# Altium schematic sheet PowerSupplyHammondMainRev1b.SchDoc(PowerSupplyHammondMainRev1b)
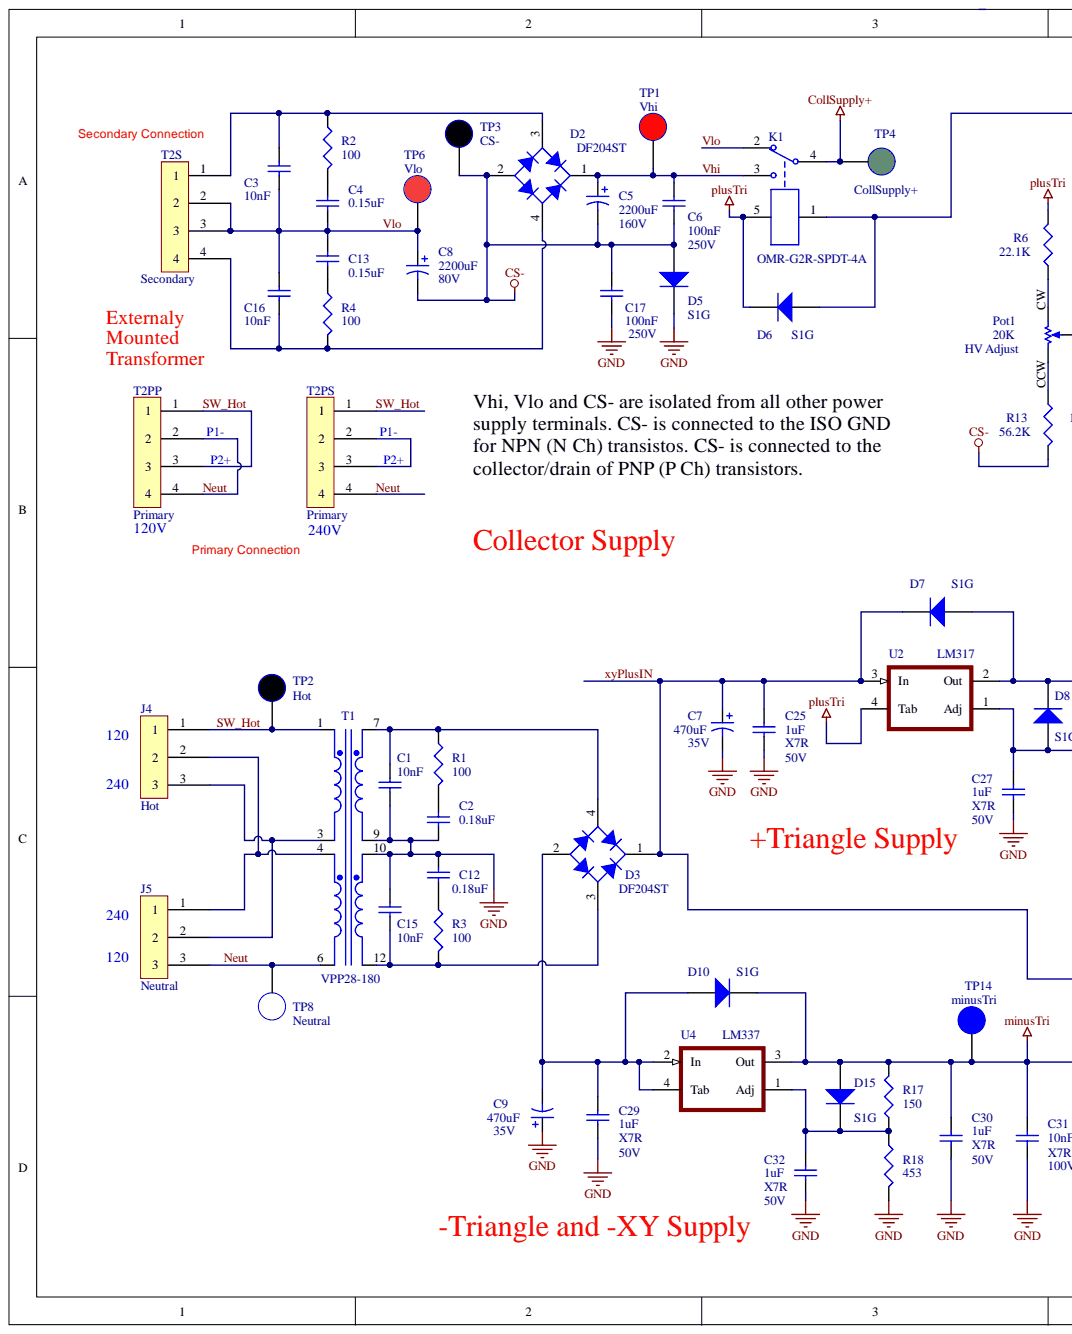
[diagram p0: PowerSupplyHammondMainRev1b.SchDoc, left]
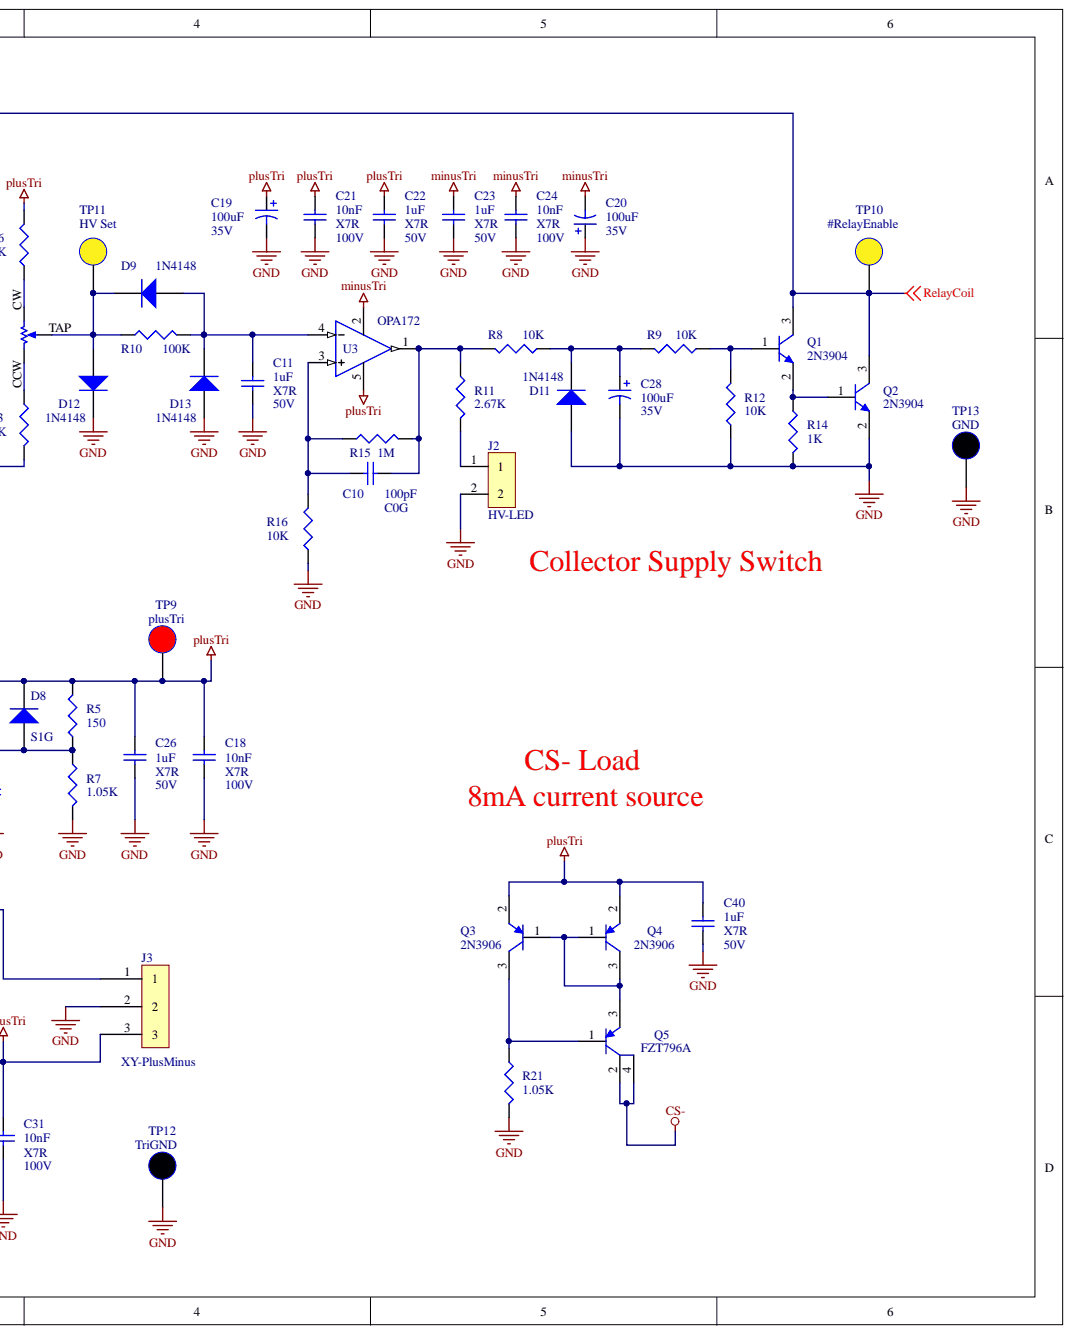
[diagram p1: PowerSupplyHammondMainRev1b.SchDoc, right]

## Parts
C1  10nF  [CAPC3216M]
C2  0.18uF  [CAPC4532M]
C3  10nF  [RAD/L7.3/W2.5/LS5.0/D0.575]
C4  0.15uF  [RAD/10.5/W6.5/LS7.5/D0.7]
C5  2200uF  Voltage Rating: 160V  [CAPR10-30-35]
C6  Capacitance: 100nF  [CAPC3216M]  (hidden comment: 0.1uF)
C7  470uF  Voltage Rating: 35V  [CAPPR5-10x12.5-STEP]
C8  2200uF  Voltage Rating (DC): 80V  [CAPR10-25-37]
C9  470uF  Voltage Rating: 35V  [CAPPR5-10x12.5-STEP]
C10  value 100pF  Temperature Coefficient: C0G  [CAPC3216M]  (hidden comment: Cap)
C11  1uF  Voltage Rating (DC): 50V  Dielectric: X7R  [CAPC3216M]
C12  0.18uF  [CAPC4532M]
C13  0.15uF  [RAD/10.5/W6.5/LS7.5/D0.7]
C15  10nF  [CAPC3216M]
C16  10nF  [RAD/L7.3/W2.5/LS5.0/D0.575]
C17  Voltage Rating: 250V  Capacitance: 100nF  [CAPC3216M]  (hidden comment: 0.1uF)
C18  10nF  Voltage Rating (DC): 100V  Dielectric: X7R  [CAPC3216M]
C19  100uF  Voltage Rating: 35V  [CAPPR2.5-6.3X10]
C20  100uF  Voltage Rating: 35V  [CAPPR2.5-6.3X10]
C21  10nF  Voltage Rating (DC): 100V  Dielectric: X7R  [CAPC3216M]
C22  1uF  Voltage Rating (DC): 50V  Dielectric: X7R  [CAPC3216M]
C23  1uF  Voltage Rating (DC): 50V  Dielectric: X7R  [CAPC3216M]
C24  10nF  Voltage Rating (DC): 100V  Dielectric: X7R  [CAPC3216M]
C25  1uF  Voltage Rating (DC): 50V  Dielectric: X7R  [CAPC3216M]
C26  1uF  Voltage Rating (DC): 50V  Dielectric: X7R  [CAPC3216M]
C27  1uF  Voltage Rating (DC): 50V  Dielectric: X7R  [CAPC3216M]
C28  100uF  Voltage Rating: 35V  [CAPPR2.5-6.3X10]
C29  1uF  Voltage Rating (DC): 50V  Dielectric: X7R  [CAPC3216M]
C30  1uF  Voltage Rating (DC): 50V  Dielectric: X7R  [CAPC3216M]
C31  10nF  Voltage Rating (DC): 100V  Dielectric: X7R  [CAPC3216M]
C32  1uF  Voltage Rating (DC): 50V  Dielectric: X7R  [CAPC3216M]
C40  1uF  Voltage Rating (DC): 50V  Dielectric: X7R  [CAPC3216M]
D2  value DF204ST  [DFS]  (hidden comment: Bridge)
D3  value DF204ST  [DFS]  (hidden comment: Bridge)
D5  S1G  [SMA-D]
D6  S1G  [SMA-D]
D7  S1G  [SMA-D]
D8  S1G  [SMA-D]
D9  1N4148  [SOD123]
D10  S1G  [SMA-D]
D11  1N4148  [SOD123]
D12  1N4148  [SOD123]
D13  1N4148  [SOD123]
D15  S1G  [SMA-D]
J2  HV-LED  [DTA-100-2]
J3  XY-PlusMinus  [DTA-100-3]
J4  Hot  [TE-EP-3]
J5  Neutral  [TE-EP-3]
K1  OMR-G2R-SPDT-4A  [G2R--1-H]
Pot1  HV Adjust  value 20K  [3306P-CCW]
Q1  2N3904  [SOT23-3M]
Q2  2N3904  [SOT23-3M]
Q3  2N3906  [SOT23-3M]
Q4  2N3906  [SOT23-3M]
Q5  FZT796A  [SOT223-4M]
R1  10  value 100  [RESC6432BM]
R2  100  [RESC6432BM]
R3  100  [RESC6432BM]
R4  100  [RESC6432BM]
R5  value 150  [RESC3216M]  (hidden comment: 100)
R6  22.1K  [RESC3216M]
R7  1.05K  [RESC3216M]
R8  10K  [RESC3216M]
R9  10K  [RESC3216M]
R10  100k  [RESC3216M]
R11  2.67K  [RESC3216M]
R12  10K  [RESC3216M]
R13  56.2K  [RESC3216M]
R14  1K  [RESC3216M]
R15  1M  [RESC3216M]
R16  10K  [RESC3216M]
R17  value 150  [RESC3216M]  (hidden comment: 100)
R18  value 453  [RESC3216M]  (hidden comment: 499)
R21  1.05K  [RESC3216M]
T1  value VPP28-180  [VPPXX-5W]  (hidden comment: TRIAD_VPP)
T2PP  Primary  [TE-EP-4]
T2PS  Primary  [TE-EP-4]
T2S  Secondary  [TE-EP-4]
TP1  Vhi  [TP-.038]
TP2  Hot  [TP-.063]
TP3  CS-  [TP-.038]
TP4  CollSupply+  [TP-.038]
TP6  Vlo  [TP-.038]
TP8  Neutral  [TP-.063]
TP9  plusTri  [TP-.038]
TP10  #RelayEnable  [TP-.038]
TP11  HV Set  [TP-.038]
TP12  TriGND  [TP-.038]
TP13  GND  [TP-.038]
TP14  minusTri  [TP-.038]
U2  LM317  [SOT223-4M]
U3  OPA172  [SOT23-5AM]
U4  LM337  [SOT223-4M]

## Nets
CollSupply+: K1.4 TP4.1
CS-: C5.2 C6.2 C8.2 C17.2 D2.2 D5.1 Q5.2 Q5.4 R13.2 TP3.1
GND: C1.2 C2.1 C7.2 C9.1 C11.2 C12.1 C15.1 C17.1 C18.1 C19.2 C20.1 C21.1 C22.2 C23.2 C24.1 C25.2 C26.2 C27.2 C28.2 C29.2 C30.2 C31.1 C32.2 C40.2 D5.2 D11.1 D12.2 D13.1 J2.2 J3.2 Q2.2 R7.2 R12.2 R14.2 R16.2 R18.2 R21.2 T1.9 T1.10 TP12.1 TP13.1
minusTri: C20.2 C23.1 C24.2 C30.1 C31.2 D10.2 D15.1 J3.3 R17.1 TP14.1 U3.2 U4.3(Out)
plusTri: C18.2 C19.1 C21.2 C22.1 C26.1 C40.1 D6.2 D7.1 D8.2 K1.5 Q3.2 Q4.2 R5.1 R6.1 TP9.1 U2.2(Out) U2.4(Tab) U3.5
RelayCoil: D6.1 K1.1 Q1.3 Q2.3 TP10.1
Neut: J5.3 T1.6 T2PP.2 T2PP.4 T2PS.4 TP8.1
SW_Hot: J4.1 T1.1 T2PP.1 T2PP.3 T2PS.1 TP2.1
Vhi: C5.1 C6.1 D2.1 K1.3 TP1.1
Vlo: C3.1 C4.2 C8.1 C13.1 C16.2 K1.2 T2S.2 T2S.3 TP6.1
xyPlusIN: C7.1 C25.1 D3.1 D7.2 J3.1 U2.3(In)
NetC1_1: C1.1 D3.4 R1.1 T1.7
NetC2_2: C2.2 R1.2
NetC3_2: C3.2 D2.3 R2.1 T2S.1
NetC4_1: C4.1 R2.2
NetC9_2: C9.2 C29.1 D3.2 D10.1 U4.2(In) U4.4(Tab)
NetC10_1: C10.1 R15.1 R16.1 U3.3
NetC10_2: C10.2 R8.1 R11.1 R15.2 U3.1
NetC11_1: C11.1 D9.1 D13.2 R10.2 U3.4
NetC12_2: C12.2 R3.1
NetC13_2: C13.2 R4.1
NetC15_2: C15.2 D3.3 R3.2 T1.12
NetC16_1: C16.1 D2.4 R4.2 T2S.4
NetC27_1: C27.1 D8.1 R5.2 R7.1 U2.1(Adj)
NetC28_1: C28.1 D11.2 R8.2 R9.1
NetC32_1: C32.1 D15.2 R17.2 R18.1 U4.1(Adj)
NetD9_2: D9.2 D12.1 Pot1.TAP R10.1 TP11.1
NetJ2_1: J2.1 R11.2
NetJ4_2: J4.2 J5.1 T1.4
NetJ4_3: J4.3 J5.2 T1.3
NetPot1_CCW: Pot1.CCW R13.1
NetPot1_CW: Pot1.CW R6.2
NetQ1_1: Q1.1 R9.2 R12.1
NetQ1_2: Q1.2 Q2.1 R14.1
NetQ3_1: Q3.1 Q4.1 Q4.3 Q5.3
NetQ3_3: Q3.3 Q5.1 R21.1
NetT2PS_2: T2PS.2 T2PS.3
N00026:

## Library data of the parts
C1 C15 = Cap: CAP PEN 10NF 100V 5% 1206; Manufacturer Part Number: CB017E0103JBA; Manufacturer: Kyocera AVX; Voltage Rating (AC): 63V; Voltage Rating (DC): 100V; Voltage Rating: 100V; Value: 10nF; Tolerance: 5%; Termination: SMD/SMT; Supplier Part Number: 478-13090-1-ND; Supplier: Digi-Key; Simulation: CAP; Signal Integrity: Cap; REACH SVHC: No SVHC; Radiation Hardening: No; Packaging: Tape and Reel; Package Quantity: 3900; Mount: Surface Mount; Min Operating Temperature: -55°C; Max Operating Temperature: 125°C; Library Name: Miscellaneous Devices.IntLib; Length: 3.3mm; Depth: 1.6mm; Contact Plating: Tin,Matte; Component Kind: Standard; Case Code (Metric): 3216; Case Code (Imperial): 1206; Case/Package: 1206; Capacitance: 10nF
C2 C12 = Cap: CAP FILM 0.18UF 5% 50VDC 1812; Manufacturer Part Number: LDECC3180JA5N00; Manufacturer: KEMET; Value: 0.18uF; Supplier Part Number: 399-18519-1-ND; Supplier: Digi-Key; Simulation: CAP; Signal Integrity: Cap; Library Name: Miscellaneous Devices.IntLib; Component Kind: Standard; Capacitance: 0.18uF
C3 C16 = Cap: CAP PET 10nF 160 VAC 250 VDC 5%; Manufacturer Part Number: R82IC2100DQ50J; Manufacturer: Kemet Arcotronics; Voltage Rating (DC): 250V; Voltage Rating (AC): 140V; Lead Diameter: 0.5mm; Dielectric Material: Polyester; Voltage: 250V; Value: 10nF; Tolerance: 5%; Termination: Radial; Supplier Part Number: 399-19339-1-ND; Supplier: Digi-Key; Simulation: CAP; Signal Integrity: Cap; Radiation Hardening: No; Packaging: Ammo Pack; Package Quantity: 3500; Mount: Through Hole; Min Operating Temperature: -55°C; Max Operating Temperature: 105°C; Library Name: Miscellaneous Devices.IntLib; Length: 7.2mm; Lead Pitch: 5mm; Height: 6.5mm; Dielectric: PET; Depth: 2.5mm; Component Kind: Standard; Case/Package: Radial; Capacitance: 10nF
C4 C13 = Cap: CAP METALLIZED POLYESTER 0.15UF TOL +-10% RADIAL 250V; Manufacturer Part Number: 154MMR250K; Manufacturer: CDE Illinois Capacitor; Voltage Rating (AC): 160V; Tolerance: 10%; Capacitance: 150nF; Width: 0.256inch; Voltage Rating (DC): 250V; Voltage Rating: 250V; Value: 0.15uF; Termination: PC Pins; Supplier Part Number: 1572-1198-ND; Supplier: Digi-Key; Simulation: CAP; Signal Integrity: Cap; Packaging: Bulk; Mount: Through Hole,PCB; Min Operating Temperature: -40°C; Max Operating Temperature: 105°C; Library Name: Miscellaneous Devices.IntLib; Length: 0.413inch; Lead Pitch: 0.295inch; Lead Free: Lead Free; Height - Seated (Max): 0.433inch; Dielectric Material: Polyester; Component Kind: Standard; Case/Package: Radial
C5 = Cap Pol3: CAP ALUM 2200UF 20% 160V SNAP; Manufacturer Part Number: 381LQ222M160K052; Manufacturer: Cornell Dubilier; Ripple Current: 2.9A; Polarity: Polar; Life (Hours): 2000Hour; ESR (Equivalent Series Resistance): 90mR; Capacitance: 2.2mF; Value: 2200uF; Tolerance: 20%; Supplier Part Number: 338-3669-ND; Supplier: Digi-Key; Simulation: CAP; Signal Integrity: Cap Pol; Packaging: Bulk; Package Quantity: 50; Mount: Through Hole; Min Operating Temperature: -40°C; Max Operating Temperature: 105°C; Library Name: Miscellaneous Devices.IntLib; Lead Pitch: 0.394inch; Height - Seated (Max): 2.047inch; Component Kind: Standard; Case/Package: Radial
C6 C17 = Cap: CAP CER 1206 0.1UF 250V X7R; Manufacturer Part Number: C1206C104KARECAUTO; Manufacturer: KEMET; Tolerance: 10%; Value: 0.1uF; Supplier Part Number: 399-17175-6-ND; Supplier: Digi-Key; Simulation: CAP; Signal Integrity: Cap; Ratings: AEC-Q200; Packaging: Cut Tape; Mount: Surface Mount; Min Operating Temperature: -55°C; Max Operating Temperature: 125°C; Library Name: Miscellaneous Devices.IntLib; Component Kind: Standard; Case Code (Metric): 3216; Case Code (Imperial): 1206; Case/Package: 1206
  C6: Voltage Rating: 250V
C7 C9 = Cap Pol3: CAP ALUM 470UF 20% 35V RADIAL; Manufacturer Part Number: 35ZL470MEFC10X20; Manufacturer: Rubycon; Ripple Current: 1.82A; Life (Hours): 4000Hour; Leakage Current: 3uA; Lead Diameter: 0.6mm; Impedance: 23mR; Dielectric Material: Aluminium; Voltage: 35V; Value: 470uF; Tolerance: 20%; Termination: Radial; Supplier Part Number: 35ZL470MEFC10X20-ND; Supplier: Digi-Key; Simulation: CAP; Signal Integrity: Cap Pol; REACH SVHC: Unknown; Radiation Hardening: No; Packaging: Bulk; Package Quantity: 1000; Mount: Through Hole; Min Operating Temperature: -40°C; Max Operating Temperature: 105°C; Library Name: Miscellaneous Devices.IntLib; Lead Pitch: 5mm; Lead Free: Lead Free; Height - Seated (Max): 0.866inch; Height: 20mm; Diameter: 10mm; Component Kind: Standard; Case/Package: Radial; Capacitance: 470uF
C8 = Cap Pol3: CAP ALUM 2200UF 20% 80V SNAP; Manufacturer Part Number: 381LX222M080H452; Manufacturer: Cornell Dubilier; Ripple Current: 2.1A; Life (Hours): 3000Hour; ESR (Equivalent Series Resistance): 90mR; Capacitance: 2.2mF; Weight: 1oz; Voltage Rating: 80V; Value: 2200uF; Tolerance: 20%; Termination: Snap in; Supplier Part Number: 338-3786-ND; Supplier: Digi-Key; Simulation: CAP; Signal Integrity: Cap Pol; Packaging: Bulk; Package Quantity: 200; Mount: Through Hole; Min Operating Temperature: -40°C; Max Operating Temperature: 105°C; Library Name: Miscellaneous Devices.IntLib; Length: 45mm; Lead Pitch: 0.394inch; Height - Seated (Max): 1.772inch; Height: 45mm; Features: General Purpose; Diameter: 22mm; Component Kind: Standard; Case/Package: Radial
C10 = Cap: CAP CER 100PF 100V NP0 1206; Manufacturer Part Number: C1206C101J1GACTU; Manufacturer: Kemet; Tolerance: ±5%; Voltage - Rated: 100V; Thickness (Max): 0.035" (0.88mm); Supplier Part Number: 399-1206-1-ND; Supplier: Digi-Key; Size / Dimension: 0.126" L x 0.063" W (3.20mm x 1.60mm); Simulation: CAP; Signal Integrity: Cap; RoHS: RoHS Compliant; Packaging: Cut Tape (CT); Package / Case: 1206 (3216 Metric); Operating Temperature: -55°C ~ 125°C; Mounting Type: Surface Mount, MLCC; Library Name: Miscellaneous Devices.IntLib; Features: Low ESL; Component Kind: Standard; Category: Capacitors; Capacitance: 100pF; Applications: General Purpose
C11 C22 C23 C25-C27 C29 C30 C32 C40 = Cap: CAP CER 1UF 50V X7R 1206; Manufacturer Part Number: C1206C105K5RACTU; Manufacturer: KEMET; Tolerance: 10%; Dielectric Material: Ceramic; Width: 0.063inch; Voltage Rating: 50V; Voltage: 50V; Value: 1uF; Thickness: 0.043inch; Termination: SMD/SMT; Supplier Part Number: 399-8147-6-ND; Supplier: Digi-Key; Simulation: CAP; Signal Integrity: Cap; Series: C; REACH SVHC: No SVHC; Radiation Hardening: No; Packaging: Tape and Reel; Package Quantity: 1; Number of Pins: 2; Mount: Surface Mount; Min Operating Temperature: -55°C; Max Operating Temperature: 125°C; Library Name: Miscellaneous Devices.IntLib; Length: 3.2mm; Height: 1mm; Depth: 1.6mm; Construction: Flat; Component Kind: Standard; Case Code (Metric): 3216; Case Code (Imperial): 1206; Case/Package: 1206; Capacitance: 1uF
C18 C21 C24 C31 = Cap: CAP CER 10NF 100V X7R 1206; Manufacturer Part Number: C1206C103K1RACTU; Manufacturer: KEMET; Tolerance: 10%; Dissipation Factor: 2.5%; Dielectric Material: Ceramic; Width: 0.063inch; Voltage Rating: 100V; Voltage: 100V; Value: 10nF; Thickness: 0.035inch; Termination: SMD/SMT; Supplier Part Number: 399-1236-6-ND; Supplier: Digi-Key; Simulation: CAP; Signal Integrity: Cap; Series: C; REACH SVHC: No SVHC; Radiation Hardening: No; Packaging: Tape and Reel; Package Quantity: 1; Number of Pins: 2; Mount: Surface Mount,PCB; Min Operating Temperature: -55°C; Max Operating Temperature: 125°C; Library Name: Miscellaneous Devices.IntLib; Length: 3.2mm; Height: 0.78mm; Depth: 1.6mm; Contact Plating: Tin,Matte; Construction: Flat; Component Kind: Standard; Case Code (Metric): 3216; Case Code (Imperial): 1206; Case/Package: 1206; Capacitance: 10nF
C19 C20 C28 = Cap Pol1: CAP ALUM 100UF 20% 35V THRU HOLE; Manufacturer Part Number: 35YXJ100M6.3X11; Manufacturer: Rubycon; Ripple Current: 400mA; Polarity: Polar; Life (Hours): 7000Hour; Leakage Current: 3uA; Lead Diameter: 0.5mm; Impedance: 220mR; Voltage: 35V; Value: 100uF; Tolerance: 20%; Termination: Radial; Supplier Part Number: 1189-2260-ND; Supplier: Digi-Key; Simulation: CAP; Signal Integrity: Cap Pol; Radiation Hardening: No; Packaging: Bulk; Package Quantity: 3000; Mount: Through Hole; Min Operating Temperature: -40°C; Max Operating Temperature: 105°C; Library Name: Miscellaneous Devices.IntLib; Lead Pitch: 2.5mm; Height - Seated (Max): 0.492inch; Height: 11mm; Diameter: 6.3mm; Component Kind: Standard; Case/Package: Radial; Capacitance: 100uF
D2 D3 = Bridge1: RECT BRIDGE GPP 400V 2A DFS; Manufacturer Part Number: DF204ST-G; Manufacturer: Comchip; Max Surge Current: 60A; Max Reverse Leakage Current: 10uA; Width: 10.41mm; Supplier Part Number: 641-1464-1-ND; Supplier: Digi-Key; Simulation: BRIDGE; Packaging: Tape and Reel; Package Quantity: 1; Number of Pins: 4; Mount: Surface Mount; Min Operating Temperature: -55°C; Max Operating Temperature: 150°C; Library Name: Miscellaneous Devices.IntLib; Length: 8.64mm; Height: 3.81mm; Component Kind: Standard; Case/Package: SMD/SMT
D5-D8 D10 D15 = Diode 1N4002: Diode Switching 400V 1A 2-Pin DO-214AC T/R; Manufacturer: ON Semiconductor / Fairchild; Reverse Recovery Time: 1.8us; Recovery Time: 1800ns; Power Dissipation: 1.4W; Polarity: Standard; Peak Reverse Current: 1uA; Peak Non-Repetitive Surge Current: 30A; Max Surge Current: 40A; Forward Voltage: 1.1V; Element Configuration: Single; Capacitance: 12pF; Width: 2.95mm; Weight: 0.106g; Voltage Rating (DC): 400V; Value: S1G; Supplier Part Number: S1GFSCT-ND; Supplier: Digi-Key; Simulation: 1N4002; Signal Integrity: 1N4002; Reverse Voltage: 400V; REACH SVHC: No SVHC; Radiation Hardening: No; Packaging: Tape and Reel; Package Quantity: 1; Output Current: 1A; Number of Pins: 2; Mount: Surface Mount; Min Operating Temperature: -55°C; Max Reverse Voltage (DC): 400V; Max Repetitive Reverse Voltage (Vrrm): 400V; Max Operating Temperature: 150°C; Max Forward Surge Current (Ifsm): 30A; Manufacturer Part Number: S1G; Library Name: Miscellaneous Devices.IntLib; Length: 4.75mm; Lead Free: Lead Free; Height: 2.2mm; Forward Current: 1A; Current Rating: 1A; Contact Plating: Tin,Matte; ComponentLink2URL: http://www.fairchildsemi.com/ds/1N%2F1N4001.pdf; ComponentLink2Description: Datasheet; ComponentLink1URL: http://www.fairchildsemi.com/; ComponentLink1Description: Manufacturer Link; Component Kind: Standard; Case/Package: SMA; Average Rectified Current: 1A
D9 D11-D13 = Diode 1N4148: DIODE GP 75V 150MA SOD123FL; Manufacturer Part Number: 1N4148WFL-G3-08; Manufacturer: Vishay Semiconductor Diodes Division; Voltage - Forward (Vf) (Max) @ If: 1.2V @ 100mA; Speed: Small Signal =< 200mA (Io), Any Speed; Reverse Recovery Time (trr): 4ns; Diode Type: Standard; Current - Reverse Leakage @ Vr: 5µA @ 75V; Voltage - DC Reverse (Vr) (Max): 75V; Value: 1N4148; Supplier Part Number: 1N4148WFL-G3-08GICT-ND; Supplier Device Package: SOD-123FL; Supplier: Digi-Key; Simulation: 1N4148; Signal Integrity: 1N4148; RoHS: RoHS Compliant; Published: 8-Jun-2000; Packaging: Cut Tape (CT); PackageReference: DO-35; PackageDescription: Diode, Axial; Body 3.8 x 1.9 mm (LxDia. max), Lead Dia. 0.53 mm (max); Package / Case: SOD-123F; Operating Temperature - Junction: 150°C (Max); Mounting Type: Surface Mount; Library Name: Miscellaneous Devices.IntLib; LatestRevisionNote: Re-released for DXP Platform.; LatestRevisionDate: 17-Jul-2002; DatasheetVersion: 1997; Current - Average Rectified (Io): 150mA; ComponentLink2URL: http://www.fairchildsemi.com/ds/1N%2F1N4148.pdf; ComponentLink2Description: Datasheet; ComponentLink1URL: http://www.fairchildsemi.com/; ComponentLink1Description: Manufacturer Link; Component Kind: Standard; Code_JEDEC: DO-204-AH; Category: Discrete Semiconductor Products; Publisher: Altium Limited
J2 = 2-Pin: CONN HEADER VERT 2POS 2.54MM; Manufacturer: TE Connectivity; Working Voltage: 250V; Value: 640456-2; Tail Length: 0.14inch; Strain Relief: No (Without); Plating Thickness: 3.81um; PCB Thickness: 0.063inch; PCB Mount Retention: Without; PCB Mounting Orientation: Vertical; Number of Positions: 2; Mating Connector Lock: Yes (With); Gender: Male; Current Rating: 5A; Contact Style: Straight; Contact Resistance: 6mR; Contact Post Length: 3.56mm; Connector Type: Connector,Pin,Plug,Header; Connector System: Wire-to-Board; Circuit Application: Signal; Approvals: CSA; Voltage Rating (AC): 250V; Voltage Rating: 250V; Termination: Solder; Supplier Part Number: A1921-ND; Supplier: Digi-Key; Shrouded: No (Without); Sealable: No (Without); REACH SVHC: No SVHC; Radiation Hardening: No; Pitch: 2.54mm; PCB Mount Alignment: No (Without); Panel Mount Retention: No (Without); Packaging: Bulk; Package Quantity: 5000; Orientation: Straight; Number of Rows: 1; Number of Poles: 2; Number of Contacts: 2; Mounting Ears: No (Without); Mount: Through Hole; Min Operating Temperature: -67°C; Max Voltage Rating (AC): 250V; Max Operating Temperature: 221°C; Mating Retention: No (Without); Mating Alignment: No (Without); Manufacturer Part Number: 640456-2; Library Name: MY_CONNECTORS.SchLib; Length: 7.62mm; Lead Pitch: 2.54mm; Lead Free: Lead Free; Insulation Material: Thermoplastic; Insulation Color: Natural; Housing Material: Polyester; Housing Color: Natural; Height: 7.87mm; Flammability Rating: UL94 V-0; Fastening Type: Friction; ELV: Compliant; Depth: 5.72mm; Contact Shape: Square; Contact Retention: No (Without); Contact Plating: Tin; Contact Mating Length: 7.49mm; Contact Material: Copper Alloy; Contact Gender: Male; Contact Current Rating: 5A; Component Kind: Standard; Color: Natural,White,Beige; Backwall/Post Interruptions: No (Without)
J3 = 3-Pin: CONN HEADER VERT 3POS 2.54MM; Manufacturer: TE Connectivity; Voltage Rating (AC): 250V; Value: 640456-3; Tail Length: 0.14inch; Strain Relief: No (Without); Plating Thickness: 3.81um; PCB Thickness: 0.063inch; PCB Mount Retention: Without; PCB Mounting Orientation: Vertical; Number of Positions: 3; Mating Connector Lock: Yes (With); Gender: Male; Current Rating: 5A; Contact Style: Straight; Contact Resistance: 6mR; Contact Post Length: 3.56mm; Connector Type: Connector,Pin,Plug,Header; Connector System: Wire-to-Board; Circuit Application: Signal; Approvals: CSA; Voltage Rating: 250V; Termination: Solder; Supplier Part Number: A19470-ND; Supplier: Digi-Key; Shrouded: No (Without); Sealable: No (Without); REACH SVHC: Unknown; Radiation Hardening: No; Pitch: 2.54mm; PCB Mount Alignment: No (Without); Panel Mount Retention: No (Without); Packaging: Bulk; Package Quantity: 5000; Orientation: Straight; Number of Rows: 1; Number of Contacts: 3; Mounting Ears: No (Without); Mount: Through Hole; Min Operating Temperature: -67°C; Max Voltage Rating (AC): 250V; Max Operating Temperature: 221°C; Mating Retention: No (Without); Mating Alignment: No (Without); Manufacturer Part Number: 640456-3; Library Name: MY_CONNECTORS.SchLib; Length: 0.4inch; Lead Free: Lead Free; Insulation Material: Thermoplastic; Insulation Color: Natural; Housing Material: Polyester; Housing Color: Natural; Height: 7.87mm; Flammability Rating: UL94 V-0; Fastening Type: Friction; ELV: Compliant; Depth: 5.72mm; Contact Shape: Square; Contact Retention: No (Without); Contact Plating: Tin; Contact Mating Length: 7.49mm; Contact Material: Copper Alloy; Contact Gender: Male; Contact Current Rating: 5A; Component Kind: Standard; Color: Beige,White; Backwall/Post Interruptions: No (Without)
J4 J5 = 3-Pin: CONN HEADER VERT 3POS 2.54MM; Manufacturer: TE Connectivity; Value: 1744037-3; Strain Relief: No (Without); Plating Thickness: 120um; Pitch: 5.08mm; PCB Thickness: 0.063mm; PCB Mount Retention: Without; PCB Mounting Orientation: Vertical; Number of Power Positions: 3; Max Voltage Rating (AC): 250V; Mating Retention: Yes (With); Contact Current Rating: 8A; Connector Type: Tab; Connector System: Wire-to-Board; Circuit Application: Power; Width: 0.323inch; Termination: Solder; Supplier Part Number: 1744037-3-ND; Supplier: Digi-Key; Series: Economy Power; Selectively Loaded: No (Without); Sealable: No (Without); PCB Mount Alignment: No (Without); Packaging: Bag; Package Quantity: 1000; Orientation: Straight; Number of Rows: 1; Number of Positions: 3; Number of Contacts: 3; Mount: Through Hole; Min Operating Temperature: -25°C; Max Operating Temperature: 105°C; Mating Alignment: Yes (With); Material: Nylon; Manufacturer Part Number: 1744037-3; Library Name: MY_CONNECTORS.SchLib; Length: 12.76mm; Lead Free: Not Applicable; Housing Material: Nylon; Housing Color: Natural; Height: 0.4291inch; Flammability Rating: UL94 V-0; ELV: Compliant; Depth: 8.52mm; Contact Type: Male Pin; Contact Retention: No (Without); Contact Plating: Tin; Contact Mating Length: 0.303inch; Contact Material: Copper Alloy; Component Kind: Standard; Color: Natural; Backwall/Post Interruptions: No (Without)
K1 = SPDT-OMR-G2R-1-H: RELAY GEN PURPOSE SPDT 5A 12V; Manufacturer: Omron; Value: G2R-1-H-DC12; Vakue: G5V-1-DC12; Release Time: 5ms; Relay Type: General Purpose; Operate Time: 15ms; Max Voltage Rating (DC): 125V; Max Voltage Rating (AC): 380V; Coil Type: Non-Latching; Coil Resistance: 400R; Coil Power: 360mW; Coil Current: 30mA; Weight: 17g; Throw Configuration: SPDT; Termination: PC Pin; Supplier Part Number: Z11701-ND; Supplier: Digi-Key; Packaging: Bulk; Package Quantity: 100; Number of Pins: 5; Mount: Through Hole; Min Operating Temperature: -40°C; Max Operating Temperature: 70°C; Max Current Rating: 5A; Manufacturer Part Number: G2R-1-H-DC12; Library Name: My_Relays.SchLib; Lead Free: Lead Free; Contact Material: Silver; Contact Current Rating: 5A; Component Kind: Standard; Coil Voltage (DC): 12V
Pot1 = POT-Single: TRIMMER 20K OHM 0.2W TH; Manufacturer Part Number: 3306P-1-203; Manufacturer: Bourns; Voltage Rating (DC): 100V; Tolerance: 25%; Temperature Coefficient: 250ppm/°C; Resistance: 20kR; Power Rating: 200mW; Pitch: 2.5mm; Number of Turns: 1; Lead Diameter: 1mm; Width: 6.81mm; Weight: 360mg; Voltage Rating: 100V; Type: Potentiometer; Termination: Pin; Taper: Linear; Supplier Part Number: 3306P-203-ND; Supplier: Digi-Key; REACH SVHC: No SVHC; Radiation Hardening: No; Packaging: Bulk; Package Quantity: 200; Number of Terminals: 3; Mount: Through Hole,PCB; Min Operating Temperature: -25°C; Military Standard: Not; Max Power Dissipation: 200mW; Max Operating Temperature: 100°C; Length: 6.81mm; Lead Free: Lead Free; Height: 7.62mm; Diameter: 6.81mm; Depth: 8.1mm; Composition: Cermet; Component Kind: Standard; Colour: Blue; Color: Blue; Library Name: MY_POTS.SchLib
Q1 Q2 = 2N3904: TRANS NPN 40V 0.2A SOT23; Manufacturer Part Number: MMBT3904LT1G; Manufacturer: ON Semiconductor; Vce Saturation (Max) @ Ib, Ic: 300mV @ 5mA, 50mA; Power - Max: 300mW; Frequency - Transition: 300MHz; DC Current Gain (hFE) (Min) @ Ic, Vce: 100 @ 10mA, 1V; Current - Collector (Ic) (Max): 200mA; Voltage - Collector Emitter Breakdown (Max): 40V; Value: 2N3904; Transistor Type: NPN; Supplier Part Number: MMBT3904LT1GOSCT-ND; Supplier Device Package: SOT-23-3 (TO-236); Supplier: Digi-Key; Simulation: 2N3904; Signal Integrity: 2N3904; RoHS: RoHS Compliant; Published: 8-Jun-2000; Part Status: Active; Packaging: Cut Tape (CT); PackageVersion: Sep-1998; PackageReference: TO-92A; Package / Case: TO-236-3, SC-59, SOT-23-3; Operating Temperature: -55°C ~ 150°C (TJ); Mounting Type: Surface Mount; Library Name: My_Miscellaneous Devices.SchLib; LatestRevisionNote: PCB Footprint 'BCY-W3/D4.7' replaced; LatestRevisionDate: 15-Jan-2003; DatasheetVersion: 1997; ComponentLink2URL: http://www.fairchildsemi.com/ds/2N%2F2N3904.pdf; ComponentLink2Description: Datasheet; ComponentLink1URL: http://www.fairchildsemi.com/; ComponentLink1Description: Manufacturer Link; Component Kind: Standard; Code_JEDEC: TO-92A; Category: Discrete Semiconductor Products; Publisher: Altium Limited
Q3 Q4 = 2N3906: TRANS PNP 40V 0.2A SOT23; Manufacturer Part Number: MMBT3906LT1G; Manufacturer: ON Semiconductor; Vce Saturation (Max) @ Ib, Ic: 400mV @ 5mA, 50mA; Power - Max: 300mW; Frequency - Transition: 250MHz; DC Current Gain (hFE) (Min) @ Ic, Vce: 100 @ 10mA, 1V; Current - Collector (Ic) (Max): 200mA; Voltage - Collector Emitter Breakdown (Max): 40V; Value: 2N3906; Transistor Type: PNP; Supplier Part Number: MMBT3906LT1GOSCT-ND; Supplier Device Package: SOT-23-3 (TO-236); Supplier: Digi-Key; Simulation: 2N3906; Signal Integrity: 2N3906; RoHS: RoHS Compliant; Packaging: Cut Tape (CT); Package / Case: TO-236-3, SC-59, SOT-23-3; Mounting Type: Surface Mount; Library Name: Miscellaneous Devices.IntLib; Component Kind: Standard; Category: Discrete Semiconductor Products
Q5 = PNP-2C: TRANS PNP 200V 0.5A SOT-223; Manufacturer Part Number: FZT796ATA; Manufacturer: Diodes Zetex; Voltage Rating (DC): -200V; Transition Frequency: 100MHz; Power Dissipation: 2W; Number of Elements: 1; Max Collector Current: 500mA; Emitter Base Voltage (VEBO): -5V; Element Configuration: Single; Current Rating: -500mA; Collector Emitter Saturation Voltage: -300mV; Width: 3.7mm; Weight: 0.000282oz; Value: FZT796A; Supplier Part Number: FZT796ACT-ND; Supplier: Digi-Key; REACH SVHC: No SVHC; Radiation Hardening: No; Polarity: PNP; Packaging: Tape and Reel; Package Quantity: 1000; Number of Pins: 4; Mount: Surface Mount; Min Operating Temperature: -55°C; Max Power Dissipation: 2W; Max Operating Temperature: 150°C; Max Frequency: 100MHz; Max Breakdown Voltage: 200V; Library Name: My_Miscellaneous Devices.SchLib; Length: 6.7mm; Lead Free: Lead Free; Height: 1.65mm; Gain Bandwidth Product: 100MHz; Frequency: 100MHz; Continuous Collector Current: -500mA; Component Kind: Standard; Collector Emitter Voltage (VCEO): 200V; Collector Emitter Breakdown Voltage: 200V; Collector Base Voltage (VCBO): 200V; Case/Package: SOT-223
R1-R4 = Res3: RES 100 OHM 1% 1W 2512; Manufacturer Part Number: RMCF2512FT100R; Manufacturer: Stackpole Electronics; Temperature Coefficient: 100ppm/°C; Resistance: 100R; Width: 0.126inch; Tolerance: 1%; Supplier: Digi-Key; Simulation: RESISTOR; Signal Integrity: Res; Radiation Hardening: No; Power Rating: 1W; Packaging: Tape and Reel; Number of Terminations: 2; Mount: Surface Mount; Min Operating Temperature: -55°C; Max Power Dissipation: 1W; Max Operating Temperature: 155°C; Library Name: Miscellaneous Devices.IntLib; Length: 0.248inch; Lead Free: Lead Free; Height: 0.028inch; Features: Automotive AEC-Q200; Contact Plating: Tin,Matte; Composition: Thick Film; Component Kind: Standard; Case Code (Metric): 6432; Case Code (Imperial): 2512; Case/Package: 2512
  R1: Supplier Part Number: RMCF2512FT100RCT-ND
  R2: Value: 100; Supplier Part Number: RMCF2512FT100RCT00-ND
  R3 R4: Value: 100; Supplier Part Number: RMCF2512FT100RCT-ND
R5 = Res3: RES 150 OHM 1% 1/4W 1206; Manufacturer Part Number: RMCF1206FT150R; Manufacturer: Stackpole Electronics; Temperature Coefficient: 100ppm/°C; Resistance: 150R; Power Rating: 250mW; Width: 0.063inch; Tolerance: 1%; Supplier Part Number: RMCF1206FT150RCT-ND; Supplier: Digi-Key; Simulation: RESISTOR; Signal Integrity: Res; Radiation Hardening: No; Packaging: Tape and Reel; Number of Terminations: 2; Mount: Surface Mount; Min Operating Temperature: -55°C; Military Standard: Not; Max Power Dissipation: 250mW; Max Operating Temperature: 155°C; Library Name: Miscellaneous Devices.IntLib; Length: 0.126inch; Lead Free: Lead Free; Height: 0.028inch; Features: Automotive AEC-Q200; Depth: 1.6mm; Contact Plating: Matte,Tin; Composition: Thick Film; Component Kind: Standard; Case Code (Metric): 3216; Case Code (Imperial): 1206; Case/Package: 1206
R6 = Res3: RES 22.1K OHM 1% 1/4W 1206; Manufacturer Part Number: RMCF1206FT22K1; Manufacturer: Stackpole Electronics; Temperature Coefficient: 100ppm/°C; Resistance: 22.1kR; Power Rating: 250mW; Width: 0.063inch; Value: 22.1K; Tolerance: 1%; Supplier Part Number: RMCF1206FT2K21CT-ND; Supplier: Digi-Key; Simulation: RESISTOR; Signal Integrity: Res; Radiation Hardening: No; Packaging: Tape and Reel; Package Quantity: 1; Number of Terminations: 2; Mount: Surface Mount; Min Operating Temperature: -55°C; Military Standard: Not; Max Power Dissipation: 250mW; Max Operating Temperature: 155°C; Library Name: Miscellaneous Devices.IntLib; Length: 0.126inch; Lead Free: Lead Free; Height: 0.028inch; Features: Automotive AEC-Q200; Depth: 1.6mm; Contact Plating: Matte,Tin; Composition: Thick Film; Component Kind: Standard; Case Code (Metric): 3216; Case Code (Imperial): 1206; Case/Package: 1206
R7 R21 = Res3: RES 1.05K OHM 1% 1/4W 1206; Manufacturer Part Number: RMCF1206FT1K05; Manufacturer: Stackpole Electronics; Temperature Coefficient: 100ppm/°C; Resistance: 1.05kR; Max Power Dissipation: 250mW; Width: 0.063inch; Value: 1.05K; Tolerance: 1%; Supplier Part Number: RMCF1206FT1K05CT-ND; Supplier: Digi-Key; Simulation: RESISTOR; Signal Integrity: Res; Radiation Hardening: No; Packaging: Tape and Reel; Number of Terminations: 2; Mount: Surface Mount; Min Operating Temperature: -55°C; Max Operating Temperature: 155°C; Library Name: Miscellaneous Devices.IntLib; Length: 0.126inch; Height: 0.028inch; Features: Automotive AEC-Q200; Contact Plating: Tin,Matte; Composition: Thick Film; Component Kind: Standard; Case Code (Metric): 3216; Case Code (Imperial): 1206; Case/Package: 1206
R8 R9 R12 R16 = Res3: RES 10K OHM 1% 1/4W 1206; Manufacturer Part Number: RMCF1206FT10K0; Manufacturer: Stackpole Electronics; Voltage Rating (DC): 200V; Temperature Coefficient: 100ppm/°C; Resistance: 10kR; Power Rating: 250mW; Width: 0.063inch; Voltage Rating: 200V; Value: 10K; Tolerance: 1%; Termination: SMD/SMT; Supplier Part Number: RMCF1206FT10K0CT-ND; Supplier: Digi-Key; Simulation: RESISTOR; Signal Integrity: Res; REACH SVHC: No SVHC; Radiation Hardening: No; Packaging: Tape and Reel; Package Quantity: 1; Number of Terminations: 2; Number of Pins: 2; Mount: Surface Mount; Min Operating Temperature: -55°C; Military Standard: Not; Max Power Dissipation: 250mW; Max Operating Temperature: 155°C; Library Name: Miscellaneous Devices.IntLib; Length: 3.2mm; Lead Free: Lead Free; Height: 0.028inch; Features: Automotive AEC-Q200; Depth: 1.6mm; Contact Plating: Matte,Tin; Composition: Thick Film; Component Kind: Standard; Case Code (Metric): 3216; Case Code (Imperial): 1206; Case/Package: 1206
R10 = Res3: RES 100K OHM 1% 1/4W 1206; Manufacturer Part Number: RMCF1206FT100K; Manufacturer: Stackpole Electronics; Temperature Coefficient: 100ppm/°C; Resistance: 100kR; Power Rating: 250mW; Width: 0.063inch; Value: 100K; Tolerance: 1%; Supplier Part Number: RMCF1206FT100KCT-ND; Supplier: Digi-Key; Simulation: RESISTOR; Signal Integrity: Res; Radiation Hardening: No; Packaging: Tape and Reel; Number of Terminations: 2; Mount: Surface Mount; Min Operating Temperature: -55°C; Military Standard: Not; Max Power Dissipation: 250mW; Max Operating Temperature: 155°C; Library Name: Miscellaneous Devices.IntLib; Length: 0.126inch; Lead Free: Lead Free; Height: 0.028inch; Features: Automotive AEC-Q200; Depth: 1.6mm; Contact Plating: Matte,Tin; Composition: Thick Film; Component Kind: Standard; Case Code (Metric): 3216; Case Code (Imperial): 1206; Case/Package: 1206
R11 = Res3: RES 2.67K OHM 1% 1/4W 1206; Manufacturer Part Number: RMCF1206FT2K74; Manufacturer: Stackpole Electronics; Temperature Coefficient: 100ppm/°C; Resistance: 2.74kR; Power Rating: 250mW; Width: 0.063inch; Value: 2.67K; Tolerance: 1%; Supplier Part Number: RMCF1206FT2K67CT-ND; Supplier: Digi-Key; Simulation: RESISTOR; Signal Integrity: Res; Radiation Hardening: No; Packaging: Tape and Reel; Number of Terminations: 2; Mount: Surface Mount; Min Operating Temperature: -55°C; Military Standard: Not; Max Power Dissipation: 250mW; Max Operating Temperature: 155°C; Library Name: Miscellaneous Devices.IntLib; Length: 0.126inch; Lead Free: Lead Free; Height: 0.028inch; Features: Automotive AEC-Q200; Depth: 1.6mm; Contact Plating: Matte,Tin; Composition: Thick Film; Component Kind: Standard; Case Code (Metric): 3216; Case Code (Imperial): 1206; Case/Package: 1206
R13 = Res3: RES 56.2K OHM 1% 1/4W 1206; Manufacturer Part Number: RMCF1206FT56K2; Manufacturer: Stackpole Electronics; Temperature Coefficient: 100ppm/°C; Resistance: 56.2kR; Power Rating: 250mW; Width: 0.063inch; Value: 56.2K; Tolerance: 1%; Supplier Part Number: RMCF1206F56K2CT-ND; Supplier: Digi-Key; Simulation: RESISTOR; Signal Integrity: Res; Radiation Hardening: No; Packaging: Tape and Reel; Package Quantity: 1; Number of Terminations: 2; Mount: Surface Mount; Min Operating Temperature: -55°C; Military Standard: Not; Max Power Dissipation: 250mW; Max Operating Temperature: 155°C; Library Name: Miscellaneous Devices.IntLib; Length: 0.126inch; Lead Free: Lead Free; Height: 0.028inch; Features: Automotive AEC-Q200; Depth: 1.6mm; Contact Plating: Tin,Matte; Composition: Thick Film; Component Kind: Standard; Case Code (Metric): 3216; Case Code (Imperial): 1206; Case/Package: 1206
R14 = Res3: RES 1K OHM 1% 1/4W 1206; Manufacturer Part Number: RMCF1206FT1K00; Manufacturer: Stackpole Electronics; Temperature Coefficient: 100ppm/°C; Resistance: 1kR; Power Rating: 250mW; Width: 0.063inch; Value: 1K; Tolerance: 1%; Supplier Part Number: RMCF1206FT1K00CT-ND; Supplier: Digi-Key; Simulation: RESISTOR; Signal Integrity: Res; Radiation Hardening: No; Packaging: Tape and Reel; Number of Terminations: 2; Mount: Surface Mount; Min Operating Temperature: -55°C; Military Standard: Not; Max Power Dissipation: 250mW; Max Operating Temperature: 155°C; Library Name: Miscellaneous Devices.IntLib; Length: 3.2mm; Lead Free: Lead Free; Height: 0.028inch; Features: Automotive AEC-Q200; Depth: 1.6mm; Contact Plating: Matte,Tin; Composition: Thick Film; Component Kind: Standard; Case Code (Metric): 3216; Case Code (Imperial): 1206; Case/Package: 1206
R15 = Res3: RES 1M OHM 1% 1/4W 1206; Manufacturer Part Number: RMCF1206FT1M00; Manufacturer: Stackpole Electronics; Temperature Coefficient: 100ppm/°C; Resistance: 1MR; Power Rating: 250mW; Width: 0.063inch; Value: 1M; Tolerance: 1%; Supplier Part Number: RMCF1206FT1M00CT-ND; Supplier: Digi-Key; Simulation: RESISTOR; Signal Integrity: Res; Radiation Hardening: No; Packaging: Tape and Reel; Number of Terminations: 2; Mount: Surface Mount; Min Operating Temperature: -55°C; Military Standard: Not; Max Power Dissipation: 250mW; Max Operating Temperature: 155°C; Library Name: Miscellaneous Devices.IntLib; Length: 0.126inch; Lead Free: Lead Free; Height: 0.028inch; Features: Automotive AEC-Q200; Depth: 1.6mm; Contact Plating: Matte,Tin; Composition: Thick Film; Component Kind: Standard; Case Code (Metric): 3216; Case Code (Imperial): 1206; Case/Package: 1206
R17 = Res3: RES 100 OHM 1% 1/4W 1206; Manufacturer Part Number: RMCF1206FT100R; Manufacturer: Stackpole Electronics; Temperature Coefficient: 100ppm/°C; Resistance: 100R; Power Rating: 250mW; Width: 0.063inch; Tolerance: 1%; Supplier Part Number: RMCF1206FT100RCT-ND; Supplier: Digi-Key; Simulation: RESISTOR; Signal Integrity: Res; Radiation Hardening: No; Packaging: Tape and Reel; Number of Terminations: 2; Mount: Surface Mount; Min Operating Temperature: -55°C; Military Standard: Not; Max Power Dissipation: 250mW; Max Operating Temperature: 155°C; Library Name: Miscellaneous Devices.IntLib; Length: 0.126inch; Lead Free: Lead Free; Height: 0.028inch; Features: Automotive AEC-Q200; Depth: 1.6mm; Contact Plating: Matte,Tin; Composition: Thick Film; Component Kind: Standard; Case Code (Metric): 3216; Case Code (Imperial): 1206; Case/Package: 1206
R18 = Res3: RES 453 OHM 1% 1/4W 1206; Manufacturer Part Number: RMCF1206FT453R; Manufacturer: Stackpole Electronics; Temperature Coefficient: 100ppm/°C; Resistance: 453R; Power Rating: 250mW; Width: 0.063inch; Tolerance: 1%; Supplier Part Number: RMCF1206FT453RCT-ND; Supplier: Digi-Key; Simulation: RESISTOR; Signal Integrity: Res; Radiation Hardening: No; Packaging: Tape and Reel; Number of Terminations: 2; Mount: Surface Mount; Min Operating Temperature: -55°C; Military Standard: Not; Max Power Dissipation: 250mW; Max Operating Temperature: 155°C; Library Name: Miscellaneous Devices.IntLib; Length: 0.126inch; Lead Free: Lead Free; Height: 0.028inch; Features: Automotive AEC-Q200; Depth: 1.6mm; Contact Plating: Matte,Tin; Composition: Thick Film; Component Kind: Standard; Case Code (Metric): 3216; Case Code (Imperial): 1206; Case/Package: 1206
T1 = TRIAD_VPP: XFRMR LAMINATED 5VA THRU HOLE; Manufacturer: Triad Magnetics; Secondary Winding(s): Dual; Power Rating: 5W; Output Voltage: 28V; Output Current: 360mA; Max Output Current: 180mA; Width: 33.32mm; Weight: 8.400001oz; Termination: PC Pin; Supplier Part Number: 237-1086-ND; Supplier: Digi-Key; Primary Winding(s): Dual; Packaging: Bulk; Package Quantity: 20; Number of Pins: 8; Mount: Through Hole; Max Power Dissipation: 5W; Manufacturer Part Number: VPP28-180; Library Name: My_Trans.SchLib; Length: 41.28mm; Lead Free: Lead Free; Height - Seated (Max): 34.93mm; Height: 34.9mm; Element Configuration: Dual; Current Rating: 360mA; Component Kind: Standard
T2PP T2PS T2S = 4-Pin: CONN HEADER VERT 4POS 2.54MM; Manufacturer: TE Connectivity; Value: 1744037-4; Strain Relief: No (Without); Plating Thickness: 120um; Pitch: 5.08mm; PCB Thickness: 0.063mm; PCB Mount Retention: Without; PCB Mounting Orientation: Vertical; Number of Power Positions: 4; Max Voltage Rating (AC): 250V; Mating Retention: Yes (With); Material: Nylon; Contact Resistance: 20mR; Contact Current Rating: 8A; Connector Type: Tab; Connector System: Wire-to-Board; Color: Natural; Circuit Application: Power; Width: 0.323inch; Termination: Solder; Supplier Part Number: 1744037-4-ND; Supplier: Digi-Key; Series: Economy Power; Selectively Loaded: No (Without); Sealable: No (Without); Radiation Hardening: No; PCB Mount Alignment: No (Without); Packaging: Bag; Package Quantity: 1000; Orientation: Straight; Number of Rows: 1; Number of Positions: 4; Number of Contacts: 4; Mount: Through Hole; Min Operating Temperature: -25°C; Max Operating Temperature: 105°C; Mating Alignment: Yes (With); Manufacturer Part Number: 1744037-4; Library Name: MY_CONNECTORS.SchLib; Length: 17.84mm; Lead Free: Not Applicable; Housing Material: Nylon; Housing Color: Natural; Height: 0.4291inch; Flammability Rating: UL94 V-0; ELV: Compliant; Depth: 8.52mm; Contact Type: Male Pin; Contact Retention: No (Without); Contact Plating: Tin; Contact Mating Length: 0.303inch; Contact Material: Copper Alloy; Component Kind: Standard; Backwall/Post Interruptions: No (Without)
TP1 TP9 = TP-Round-Red: TP Thru-Hole; Manufacturer Part Number: Gold; Manufacturer: UW; Value: TP Thru-Hole; Supplier Part Num,ber: Gold; Supplier: UW; Library Name: MY_TP.SchLib; Component Kind: Standard
TP2 TP3 TP12 TP13 = TP-Round-Black: TP Thru-Hole; Manufacturer Part Number: Gold; Manufacturer: UW; Value: TP Thru-Hole; Library Name: MY_TP.SchLib; Component Kind: Standard
  TP2: Supplier Part Number: Gold
  TP3 TP12 TP13: Supplier Part Num,ber: Gold; Supplier: UW
TP4 = TP-Round-Green: TP Thru-Hole; Manufacturer Part Number: Gold; Manufacturer: UW; Value: TP Thru-Hole; Supplier Part Num,ber: Gold; Supplier: UW; Library Name: MY_TP.SchLib; Component Kind: Standard
TP6 = TP-Round-Orange: TP Thru-Hole; Manufacturer Part Number: Gold; Manufacturer: UW; Value: TP Thru-Hole; Supplier Part Num,ber: Gold; Supplier: UW; Library Name: MY_TP.SchLib; Component Kind: Standard
TP8 = TP-Round-White: TP Thru-Hole; Manufacturer Part Number: Gold; Manufacturer: UW; Value: TP Thru-Hole; Supplier Part Number: Gold; Supplier: UW; Library Name: MY_TP.SchLib; Component Kind: Standard
TP10 TP11 = TP-Round-Yellow: TP Thru-Hole; Manufacturer Part Number: Gold; Manufacturer: UW; Value: TP Thru-Hole; Supplier Part Num,ber: Gold; Supplier: UW; Library Name: MY_TP.SchLib; Component Kind: Standard
TP14 = TP-Round-Blue: TP Thru-Hole; Manufacturer Part Number: Gold; Manufacturer: UW; Value: TP Thru-Hole; Supplier Part Num,ber: Gold; Supplier: UW; Library Name: MY_TP.SchLib; Component Kind: Standard
U2 = LM317: LDO Pos 1.2V to 37V 1A 4-Pin SOT-223; Manufacturer Part Number: LM317AEMPX/NOPB; Manufacturer: TI National Semiconductor; Reference Voltage: 1.262V; Quiescent Current: 60uA; Power Supply Rejection Ratio (PSRR): 80dB; Polarity: Positive; Output Voltage Accuracy: 1%; Output Type: Adjustable; Number of Regulators: 1; Min Supply Voltage: 3V; Min Input Voltage: 4.2V; Min Current Limit: 1.5A; Max Supply Voltage: 40V; Dropout Voltage: 170mV; Width: 3.56mm; Weight: 0.006632oz; Voltage: 37V; Value: LM317; Termination: SMD/SMT; Supplier Part Number: LM317AEMPX/NOPBCT-ND; Supplier: Digi-Key; REACH SVHC: No SVHC; Radiation Hardening: No; Packaging: Tape and Reel; Package Quantity: 1000; Output Voltage: 37V; Output Current: 1A; Number of Pins: 4; Number of Outputs: 1; Mount: Surface Mount; Min Output Voltage: 1.2V; Min Operating Temperature: -40°C; Max Output Voltage: 37V; Max Output Current: 1A; Max Operating Temperature: 125°C; Max Input Voltage: 40V; Length: 6.5mm; Lead Free: Lead Free; Height: 1.6mm; Current Rating: 1A; Contact Plating: Tin,Matte; Component Kind: Standard; Case/Package: SOT-223; Accuracy: 1%; Library Name: MY_Vregs.SchLib
U3 = TLV2371_5SOT23: OPA172IDCKT 10 MHz,10 V/s, 4.5V to 36V, SC-70; Manufacturer Part Number: OPA172IDBVR; Manufacturer: Texas Instruments; Voltage Gain: 130dB; Value: TLV271; Slew Rate: 10V/µs; Power Supply Rejection Ratio (PSRR): -120dB; Output Current per Channel: 75mA; Operating Supply Current: 1.6mA; Number of Circuits: 1; Min Dual Supply Voltage: 2.25V; Max Dual Supply Voltage: 18V; Input Offset Voltage (Vos): 200uV; Input Bias Current: 8pA; Gain Bandwidth Product: 10MHz; Common Mode Rejection Ratio: 90dB; Supplier Part Number: 296-40433-1-ND; Supplier: Digi-Key; Simulation: TLV2371; REACH SVHC: No SVHC; Packaging: Tape and Reel; Number of Pins: 5; Number of Channels: 1; Number of Amplifiers: 1; Mount: Surface Mount; Min Supply Voltage: 4.5V; Min Operating Temperature: -40°C; Max Supply Voltage: 36V; Max Operating Temperature: 125°C; Lead Free: Lead Free; Contact Plating: Gold; Component Kind: Standard; Case/Package: SOT-23-5; Bandwidth: 10MHz; Library Name: TI-OPAMPS.SchLib
U4 = LM337: LDO Neg -1.2V to -37V 1A 4-Pin SOT-223; Manufacturer Part Number: LM337IMP/NOPB; Manufacturer: Texas Instruments; Tolerance: 3%; Reference Voltage: -1.287V; Quiescent Current: 3mA; Power Supply Rejection Ratio (PSRR): 77dB; Power Dissipation: 2W; Polarity: Negative; Output Type: Adjustable; Number of Outputs: 1; Min Input Voltage: -4.2V; Max Input Voltage: -50V; Dropout Voltage: 2.4V; Width: 3.56mm; Weight: 0.006632oz; Value: LM337; Supplier Part Number: LM337IMP/NOPBCT-ND; Supplier: Digi-Key; REACH SVHC: No SVHC; Packaging: Tape and Reel; Output Voltage: -37V; Output Current: 1A; Number of Pins: 4; Mount: Surface Mount; Min Output Voltage: -37V; Min Operating Temperature: -40°C; Max Power Dissipation: 2W; Max Output Voltage: -1.2V; Max Output Current: 1A; Max Operating Temperature: 125°C; Length: 6.5mm; Lead Free: Lead Free; Height: 1.6mm; Component Kind: Standard; Case/Package: SOT-223; Library Name: MY_Vregs.SchLib
